# Altium schematic sheet Actions Icarus Board.schdoc("Actions Icarus Board")
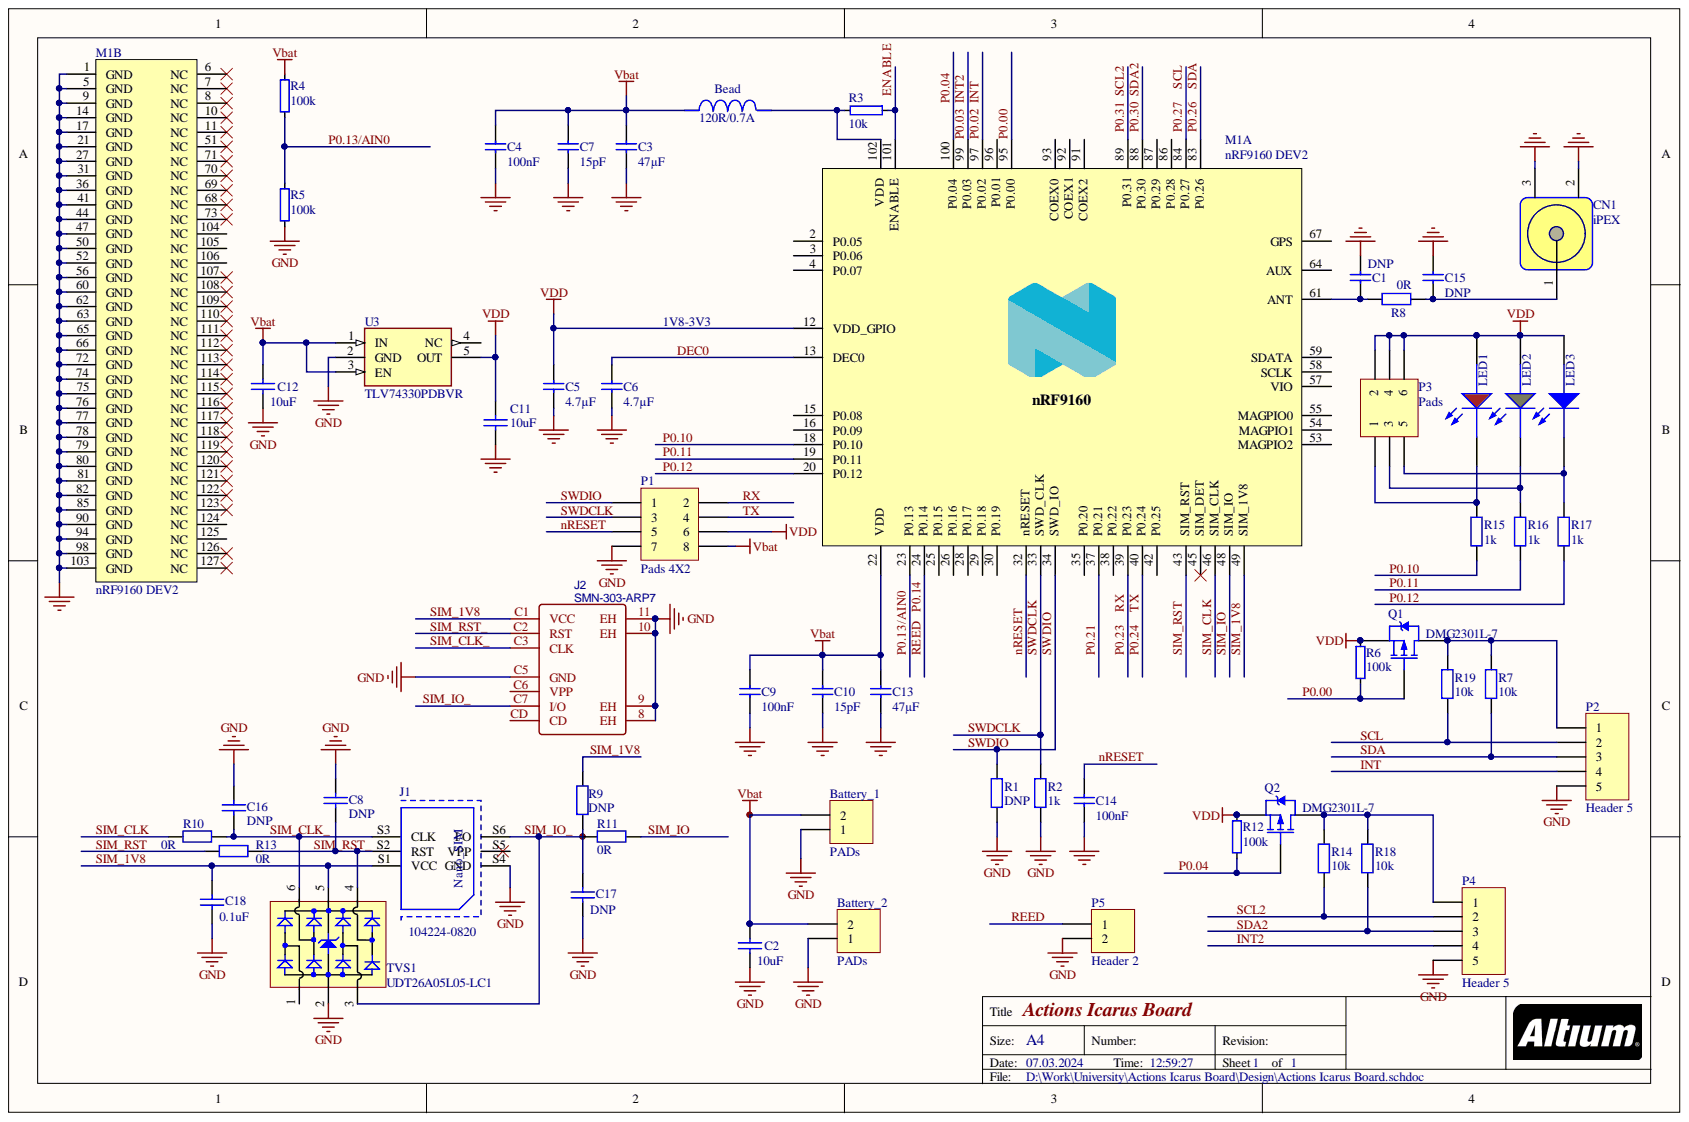
[diagram p0: Actions Icarus Board.schdoc]

## Parts
Battery_1  PADs  [HDR1X2]
Battery_2  PADs  [HDR1X2]
Bead  value 120R/0.7A  [INDC2012X145N]  (hidden comment: TYS50406R8M-10)
C1  DNP  [CAPC1608X100N]
C2  10uF  [CAPC1608X100N]
C3  47µF  [CAPC3216X100N]
C4  100nF  [CAPC1608X100N]
C5  4.7µF  [CAPC1608X100N]
C6  4.7µF  [CAPC1608X100N]
C7  15pF  [CAPC1608X100N]
C8  value DNP  [CAPC1608X100N]  (hidden comment: 10pF)
C9  100nF  [CAPC1608X100N]
C10  15pF  [CAPC1608X100N]
C11  10uF  [CAPC1608X100N]
C12  10uF  [CAPC1608X100N]
C13  47µF  [CAPC3216X100N]
C14  100nF  [CAPC1608X100N]
C15  DNP  [CAPC1608X100N]
C16  value DNP  [CAPC1608X100N]  (hidden comment: 10pF)
C17  value DNP  [CAPC1608X100N]  (hidden comment: 10pF)
C18  0.1uF  [CAPC1608X100N]
CN1  iPEX  [HIROSE_U.FL-R-SMT-1(10)]
J1  104224-0820  [1042240820]
J2  SMN-303-ARP7  [SIM-SMD_SMN-303-ARP7]
LED1  LED RED  [LEDC1608X80N_RED]  (value not drawn)
LED2  LED GREEN  [LEDC1608X80N_RED]  (value not drawn)
LED3  LED BLUE  [LEDC1608X80N_RED]  (value not drawn)
M1  nRF9160 DEV2  Supplier part number: nRF9160  [nRF9160_DEV2_MODULE]  (parts A,B)
P1  Pads 4X2  [HDR2X4]
P2  Header 5  [HDR1X5]
P3  Pads  [HDR2X3]
P4  Header 5  [HDR1X5]
P5  Header 2  [HDR1X2]
Q1  DMG2301L-7  [SOT95P230X112-3N]
Q2  DMG2301L-7  [SOT95P230X112-3N]
R1  DNP  [RESC1608X50N]
R2  1k  [RESC1608X50N]
R3  10k  [RESC1608X50N]
R4  100k  [RESC1608X50N]
R5  100k  [RESC1608X50N]
R6  100k  [RESC1608X50N]
R7  10k  [RESC1608X50N]
R8  0R  [RESC1608X50N]
R9  DNP  [RESC1608X50N]
R10  0R  [RESC1608X50N]
R11  0R  [RESC1608X50N]
R12  100k  [RESC1608X50N]
R13  0R  [RESC1608X50N]
R14  10k  [RESC1608X50N]
R15  1k  [RESC1608X50N]
R16  1k  [RESC1608X50N]
R17  1k  [RESC1608X50N]
R18  10k  [RESC1608X50N]
R19  10k  [RESC1608X50N]
TVS1  UDT26A05L05-LC1  [SOT95P280X100-6N]
U3  TLV74330PDBVR  [SOT95P270X145-5N]

## Nets
GND: Battery_1.1 Battery_2.1 C1.1 C2.2 C3.2 C4.2 C5.2 C6.2 C7.2 C8.1 C9.2 C10.2 C11.2 C12.2 C13.2 C14.2 C15.1 C16.1 C17.1 C18.1 CN1.2 CN1.3 J1.0 J1.S4(GND) J2.8(EH) J2.9(EH) J2.10(EH) J2.11(EH) J2.C5(GND) M1.1(GND) M1.5(GND) M1.9(GND) M1.14(GND) M1.17(GND) M1.21(GND) M1.27(GND) M1.31(GND) M1.36(GND) M1.41(GND) M1.44(GND) M1.47(GND) M1.50(GND) M1.52(GND) M1.56(GND) M1.60(GND) M1.62(GND) M1.63(GND) M1.65(GND) M1.66(GND) M1.72(GND) M1.74(GND) M1.75(GND) M1.76(GND) M1.77(GND) M1.78(GND) M1.79(GND) M1.80(GND) M1.81(GND) M1.82(GND) M1.85(GND) M1.90(GND) M1.94(GND) M1.98(GND) M1.103(GND) P1.7 P2.5 P4.5 P5.2 R1.2 R2.2 R5.1 TVS1.2 U3.2(GND)
Vbat: Battery_1.2 Battery_2.2 Bead.1 C2.1 C3.1 C4.1 C7.1 C9.1 C10.1 C12.1 C13.1 M1.22(VDD) P1.8 R4.2 U3.1(IN) U3.3(EN)
VDD: C5.1 C11.1 LED1.1 LED2.1 LED3.1 M1.12(VDD_GPIO) P1.6 P3.2 P3.4 P3.6 Q1.2 Q2.2 R6.2 R12.2 U3.5(OUT)
DEC0: C6.1 M1.13(DEC0)
ENABLE: M1.101(ENABLE) R3.2
INT: M1.97(P0.02) P2.4
INT2: M1.99(P0.03) P4.4
nRESET: C14.1 M1.32(nRESET) P1.5
P0.00: M1.95(P0.00) Q1.1 R6.1
P0.04: M1.100(P0.04) Q2.1 R12.1
P0.10: M1.18(P0.10) R15.2
P0.11: M1.19(P0.11) R16.2
P0.12: M1.20(P0.12) R17.2
P0.13/AIN0: M1.23(P0.13) R4.1 R5.2
P0.14: M1.24(P0.14) P5.1
P0.21: M1.37(P0.21)
P0.23: M1.39(P0.23) P1.2
P0.24: M1.40(P0.24) P1.4
P0.26: M1.83(P0.26) P2.3 R7.2
P0.27: M1.84(P0.27) P2.2 R19.2
P0.30: M1.88(P0.30) P4.3 R18.2
P0.31: M1.89(P0.31) P4.2 R14.2
SIM_1V8: C18.2 J1.S1(VCC) J2.C1(VCC) M1.49(SIM_1V8) R9.2 TVS1.5
SIM_CLK: M1.46(SIM_CLK) R10.2
SIM_CLK_: C16.2 J1.S3(CLK) J2.C3(CLK) R10.1 TVS1.6
SIM_IO: M1.48(SIM_IO) R11.1
SIM_IO_: C17.2 J1.S6(I/O) J2.C7(I/O) R9.1 R11.2 TVS1.3
SIM_RST: M1.43(SIM_RST) R13.2
SIM_RST_: C8.2 J1.S2(RST) J2.C2(RST) R13.1 TVS1.4
SWDCLK: M1.33(SWD_CLK) P1.3 R2.1
SWDIO: M1.34(SWD_IO) P1.1 R1.1
NetBead_2: Bead.2 M1.102(VDD) R3.1
NetC1_2: C1.2 M1.61(ANT) R8.1
NetC15_2: C15.2 CN1.1 R8.2
NetLED1_2: LED1.2 P3.1 R15.1
NetLED2_2: LED2.2 P3.3 R16.1
NetLED3_2: LED3.2 P3.5 R17.1
NetP2_1: P2.1 Q1.3 R7.1 R19.1
NetP4_1: P4.1 Q2.3 R14.1 R18.1

Not connected: J1 S5(VPP); J2 C6(VPP) CD; M1 2(P0.05) 3(P0.06) 4(P0.07) 6(NC) 7(NC) 8(NC) 10(NC) 11(NC) 15(P0.08) 16(P0.09) 25(P0.15) 26(P0.16) 28(P0.17) 29(P0.18) 30(P0.19) 35(P0.20) 38(P0.22) 42(P0.25) 45(SIM_DET) 51(NC) 53(MAGPIO2) 54(MAGPIO1) 55(MAGPIO0) 57(VIO) 58(SCLK) 59(SDATA) 64(AUX) 67(GPS) 68(NC) 69(NC) 70(NC) 71(NC) 73(NC) 86(P0.28) 87(P0.29) 91(COEX2) 92(COEX1) 93(COEX0) 96(P0.01) 104(NC) 105(NC) 106(NC) 107(NC) 108(NC) 109(NC) 110(NC) 111(NC) 112(NC) 113(NC) 114(NC) 115(NC) 116(NC) 117(NC) 118(NC) 119(NC) 120(NC) 121(NC) 122(NC) 123(NC) 124(NC) 125(NC) 126(NC) 127(NC); TVS1 1; U3 4(NC)

## Library data of the parts
Battery_1 Battery_2 P5 = Header 2: Header, 2-Pin; Signal Integrity: Connector; Library Name: Miscellaneous Connectors.IntLib; LatestRevisionNote: Re-released for DXP Platform.; LatestRevisionDate: 17-Jul-2002; Component Kind: Standard; Publisher: Altium Limited
Bead = PFL3215-102MEB: Unknown; PackageReference: TYS50406R8M-10; Manufacturer Part Number: TYS50406R8M-10; Library Name: TI WEBENCH; Component Kind: Standard
C1 C15 = C0402-4µ7: Capacitor, X5R, ±20%; Part number IPS: 00000001251; Value: 100nF; Note: 10V; Database Table Name: Capacitors$; Artikkelnr Noca: 20039482; Supplier Part Number 1: 490-13252-1-ND; Supplier 1: Digi-Key; Published: 2009-05-08; Pin Count: 2; Part Number: C0402-4µ7; Library Name: Nordic Passive DB.DbLib; LatestRevisionDate: 2017-11-09; Footprint Doc: 0402; ComponentLink2Description: Supplier link; ComponentLink1Description: Datasheet; Component Kind: Standard; Publisher: Nordic Semiconductor
C2 C11 C12 = CAP: Capacitor; 6. MANUF INST: ALTERNATIVE; 9. TYPE: SMD; 8. PINS: 2; 7. PACKAGE: 0603; 4. MAN P/N: GCJ188R71E104KA12D; 3. MAN BRAND: Murata; 2. VALUE: MLCC 100nF 25V 10% X7R; Simulation: CAP; Signal Integrity: Cap; Library Name: Miscellaneous Devices.IntLib; Component Kind: Standard; 5. DATASHEET LINK: https://www.mouser.com/datasheet/2/281/c03e-516224.pdf
C3-C7 C9 C10 C13 C14 = C0402-4µ7: Capacitor, X5R, ±20%; Part number IPS: 00000001251; Note: 10V; Database Table Name: Capacitors$; Artikkelnr Noca: 20039482; Supplier Part Number 1: 490-13252-1-ND; Supplier 1: Digi-Key; Published: 2009-05-08; Pin Count: 2; Part Number: C0402-4µ7; Library Name: Nordic Passive DB.DbLib; LatestRevisionDate: 2017-11-09; Footprint Doc: 0402; ComponentLink2Description: Supplier link; ComponentLink1Description: Datasheet; Component Kind: Standard; Publisher: Nordic Semiconductor
  C3 C13: Value: 47µF
  C4 C9 C14: Value: 100nF
  C5 C6: Value: 4.7µF
  C7 C10: Value: 15pF
C8 C16-C18 = Cap: Capacitor; Simulation: CAP; Signal Integrity: Cap; Library Name: Miscellaneous Devices.IntLib; Component Kind: Standard
  C18: Value: 0.1uF
CN1 = Component_1: Connector; 6. MANUF INST: ALTERNATIVE; 4. MAN P/N: U.FL-R-SMT-1(60); 3. MAN BRAND: Hirose; 2. VALUE: UFL SMD 50ohm Female; Library Name: UFL connector.SchLib; Component Kind: Standard; 5. DATASHEET LINK: http://www.mouser.com/ds/2/185/ed_UFL_20141014-515434.pdf
J1 = 693043020611: WR-CRD Nano SIM Card Connector, Push & Pull; Library Name: Card Connectors WR-CRD.SchLib; Component Kind: Standard
J2 = SMN-303-ARP7: Manufacturer: XUNPU(讯普); Contributor: lcsc; Supplier Part: C5137194; Supplier: LCSC; spiceSymbolName: SMN-303-ARP7; spicePre: U; Manufacturer Part: SMN-303-ARP7; JLCPCB Part Class: Extended Part; Component Kind: Standard
M1 = nRF9160_DEV2_MODULE_DK: nRF9160 MODULE, SiP LGA; Part number Sanmina: LFNPNSM800002; Manufacturer: Nordic Semiconductor; Delivery: X; Database Table Name: Finland$; Value: nRF9160 DEV2; Supplier: Nordic Semiconductor; Pin Count: 161; Part Number: nRF9160_DEV2_MODULE_DK; Manufacturer Part Number: nRF9160; Library Name: Nordic Finland DB.DbLib; Footprint Doc: 16.0*10.5*1.1; Component Kind: Standard
P1 = Header 4X2: Header, 4-Pin, Dual row; Signal Integrity: Connector; Library Name: Miscellaneous Connectors.IntLib; LatestRevisionNote: Re-released for DXP Platform.; LatestRevisionDate: 17-Jul-2002; Component Kind: Standard; Publisher: Altium Limited
P2 P4 = Header 5: Header, 5-Pin; Signal Integrity: Connector; Library Name: Miscellaneous Connectors.IntLib; LatestRevisionNote: Re-released for DXP Platform.; LatestRevisionDate: 17-Jul-2002; Component Kind: Standard; Publisher: Altium Limited
P3 = Header 3X2: Header, 3-Pin, Dual row; Signal Integrity: Connector; Library Name: Miscellaneous Connectors.IntLib; LatestRevisionNote: Re-released for DXP Platform.; LatestRevisionDate: 17-Jul-2002; Component Kind: Standard; Publisher: Altium Limited
Q1 Q2 = PMOS-2: P-Channel Power MOSFET; Note: Pin 4 not drawn and electrically connected to Pin 2.; Simulation: IRF9510; Published: 8-Jun-2000; PackageVersion: Feb-1997; PackageReference: TO-220AB; PackageDescription: TO, Thru-Hole, Vertical, Heatsink Mounted; 3 In-Line Leads; Pitch 2.54 mm; Library Name: Miscellaneous Devices.IntLib; LatestRevisionNote: Re-released for DXP Platform.; LatestRevisionDate: 17-Jul-2002; DatasheetVersion: PD-9.390D; ComponentLink1URL: http://www.irf.com/; ComponentLink1Description: Manufacturer Link; Component Kind: Standard; Publisher: Altium Limited
R1 R9 = R_EU: Resistor; Value: DNP; Simulation: RESISTOR; Library Name: Passives.SchLib; LatestRevisionDate: 27-Jul-20  -  Semtech; Component Kind: Standard
R2 R7 R8 R10 R11 R13-R19 = R_EU: Resistor; Manufacturer Part Number: RC0201FR-071KL; Simulation: RESISTOR; Library Name: Passives.SchLib; LatestRevisionDate: 27-Jul-20  -  Semtech; Component Kind: Standard
  R2 R15-R17: Value: 1k
  R7 R14 R18 R19: Value: 10k
  R8 R10 R11 R13: Value: 0R
R3-R6 R12 = R0201-10k: Resistor, ±1%, 0.05W; Part number IPS: 00000001255; Note: Chip Res 1%; Database Table Name: Resistors$; Artikkelnr Noca: N.A.; Published: 2014-11-13; Pin Count: 2; Part Number: R0201-10k; Library Name: Nordic Passive DB.DbLib; LatestRevisionDate: 2014-11-13; Footprint Doc: 0201; ComponentLink2Description: Supplier link; ComponentLink1Description: Datasheet; Component Kind: Standard; Publisher: Nordic Semiconductor
  R3: Value: 10k
  R4-R6 R12: Value: 100k
TVS1 = TVS/SOT23-6L: UDT26A05L05; Component Kind: Standard; Library Name: SCHїв.SCHLIB
U3 = MIC5216-5.0YM5-TR: Power Supply; Component Kind: Standard
LED1 = LED RED: Description: LED RED; Component Kind: Standard; Library Name: Actions Icarus Board.SCHLIB
LED2 = LED GREEN: Description: LED GREEN; Component Kind: Standard; Library Name: Actions Icarus Board.SCHLIB
LED3 = LED BLUE: Description: LED BLUE; Component Kind: Standard; Library Name: Actions Icarus Board.SCHLIB
